# Altium schematic sheet COMPONENT BLOCKS 1.SchDoc
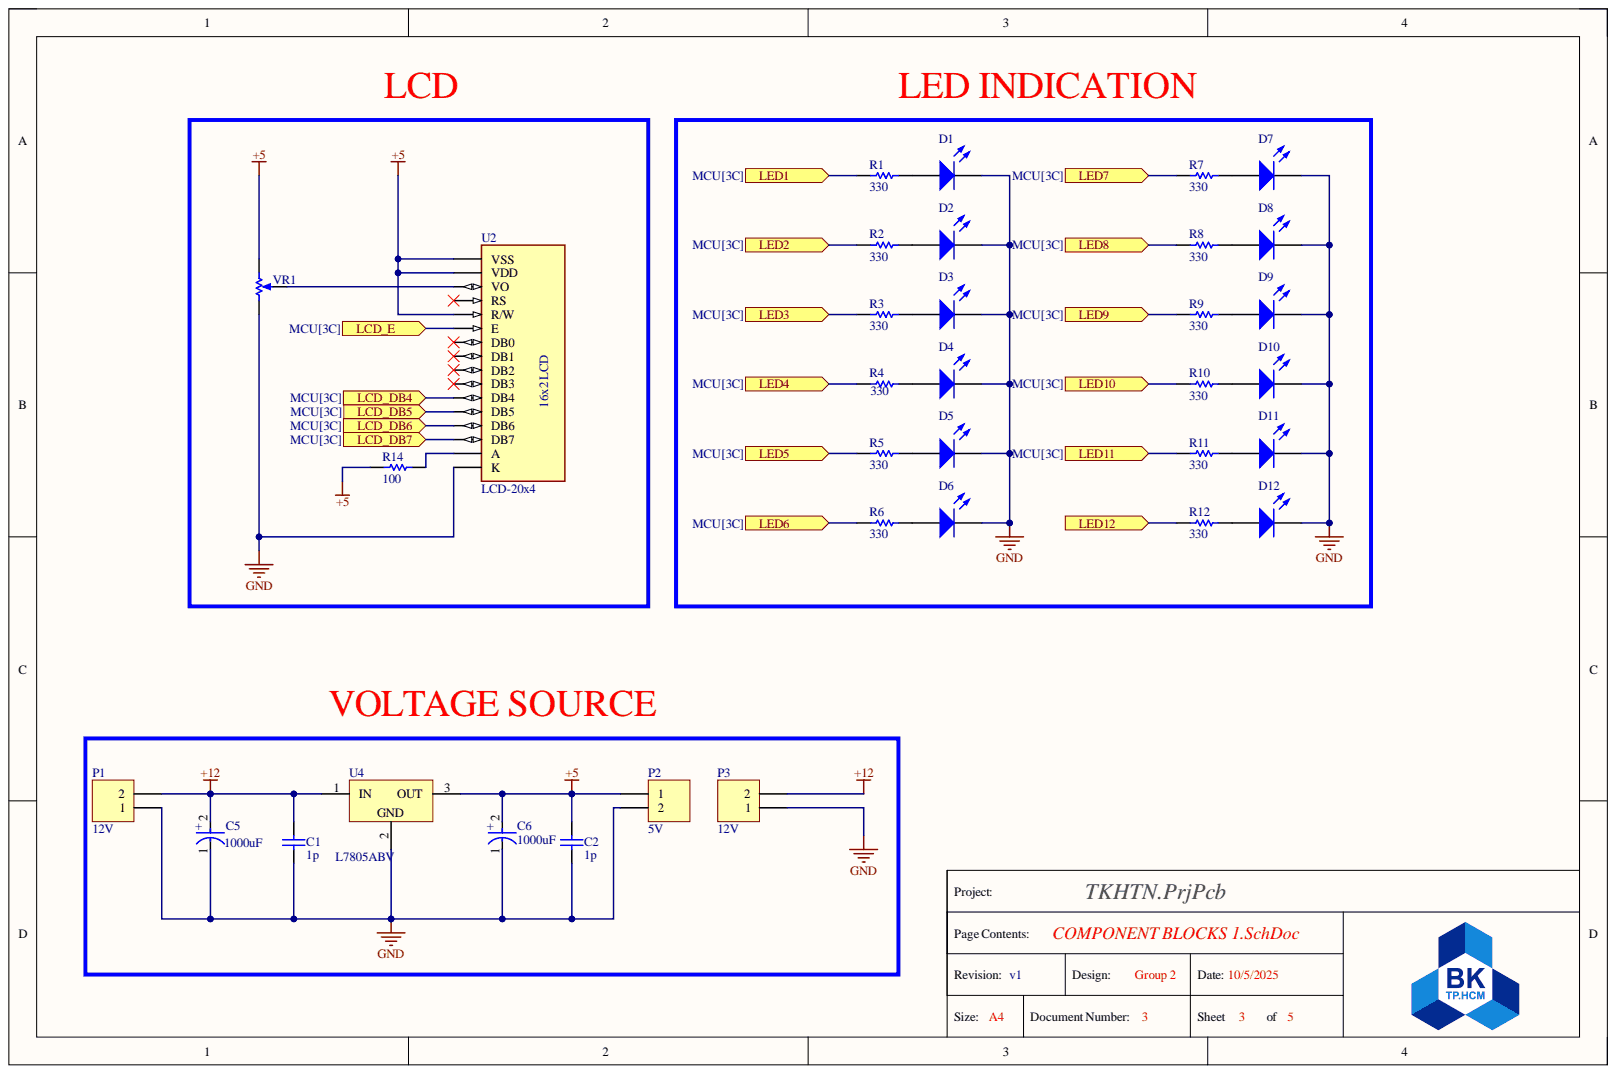
[diagram p0: COMPONENT BLOCKS 1.SchDoc]

## Parts
C1  value 1p  (hidden comment: Capacitor)
C2  value 1p  (hidden comment: Capacitor)
C5  1000uF  [FP-SMR-P45-MFG]
C6  1000uF  [FP-SMR-P45-MFG]
D1  Light-Emitting Diode  (value not drawn)
D2  Light-Emitting Diode  (value not drawn)
D3  Light-Emitting Diode  (value not drawn)
D4  Light-Emitting Diode  (value not drawn)
D5  Light-Emitting Diode  (value not drawn)
D6  Light-Emitting Diode  (value not drawn)
D7  Light-Emitting Diode  (value not drawn)
D8  Light-Emitting Diode  (value not drawn)
D9  Light-Emitting Diode  (value not drawn)
D10  Light-Emitting Diode  (value not drawn)
D11  Light-Emitting Diode  (value not drawn)
D12  Light-Emitting Diode  (value not drawn)
P1  12V  [HDR1X2]
P2  5V  [HDR1X2]
P3  12V  [HDR1X2]
R1  value 330  (hidden comment: Resistor)
R2  value 330  (hidden comment: Resistor)
R3  value 330  (hidden comment: Resistor)
R4  value 330  (hidden comment: Resistor)
R5  value 330  (hidden comment: Resistor)
R6  value 330  (hidden comment: Resistor)
R7  value 330  (hidden comment: Resistor)
R8  value 330  (hidden comment: Resistor)
R9  value 330  (hidden comment: Resistor)
R10  value 330  (hidden comment: Resistor)
R11  value 330  (hidden comment: Resistor)
R12  value 330  (hidden comment: Resistor)
R14  value 100  (hidden comment: Resistor)
U2  LCD-20x4  [MODULE_LCD-16X2]
U4  L7805ABV  [TO220]
VR1  Potentiometer  (value not drawn)

## Ports
LCD_DB4, LCD_DB5, LCD_DB6, LCD_DB7, LCD_E, LED1, LED2, LED3, LED4, LED5, LED6, LED7, LED8, LED9, LED10, LED11, LED12

## Nets
+5: C2.2 C6.2 P2.1 R14.1 U2.1(VSS) U2.2(VDD) U2.5(R/W) U4.3 VR1.2
+12: C1.2 C5.2 P1.2 P3.2 U4.1
GND: C1.1 C2.1 C5.1 C6.1 D1.2 D2.2 D3.2 D4.2 D5.2 D6.2 D7.2 D8.2 D9.2 D10.2 D11.2 D12.2 P1.1 P2.2 P3.1 U2.16(K) U4.2 VR1.1
LCD_DB4: U2.11(DB4) ; port LCD_DB4
LCD_DB5: U2.12(DB5) ; port LCD_DB5
LCD_DB6: U2.13(DB6) ; port LCD_DB6
LCD_DB7: U2.14(DB7) ; port LCD_DB7
LCD_E: U2.6(E) ; port LCD_E
LED1: R1.1 ; port LED1
LED2: R2.1 ; port LED2
LED3: R3.1 ; port LED3
LED4: R4.1 ; port LED4
LED5: R5.1 ; port LED5
LED6: R6.1 ; port LED6
LED7: R7.1 ; port LED7
LED8: R8.1 ; port LED8
LED9: R9.1 ; port LED9
LED10: R10.1 ; port LED10
LED11: R11.1 ; port LED11
LED12: R12.1 ; port LED12
NetD1_1: D1.1 R1.2
NetD2_1: D2.1 R2.2
NetD3_1: D3.1 R3.2
NetD4_1: D4.1 R4.2
NetD5_1: D5.1 R5.2
NetD6_1: D6.1 R6.2
NetD7_1: D7.1 R7.2
NetD8_1: D8.1 R8.2
NetD9_1: D9.1 R9.2
NetD10_1: D10.1 R10.2
NetD11_1: D11.1 R11.2
NetD12_1: D12.1 R12.2
NetR14_2: R14.2 U2.15(A)
NetU2_3: U2.3(VO) VR1.3

Not connected: U2 4(RS) 7(DB0) 8(DB1) 9(DB2) 10(DB3)

## Library data of the parts
C1 C2 = Capacitor: SimulationGenericComponent: True; Component Kind: Standard; Description: Capacitor; Library Name: Simulation Generic Components.IntLib; Simulation: C_Capacitor_Default
C5 C6 = CMP-33768-000007-1: CAP ALUM 270UF 20% 450V SNAP; Life (Hours): 2000Hour; Polarity: Polar; Ripple Current: 1.88A; Capacitance: 1000uF; Case/Package: Radial; Component Kind: Standard; Height - Seated (Max): 1.87inch; Lead Pitch: 0.394inch; Max Operating Temperature: 85°C; Min Operating Temperature: -25°C; Mount: Through Hole; Package Quantity: 200; Packaging: Bulk; Tolerance: 20%; Voltage Rating: 450V; Library Name: capacitor polarized.IntLib
D1-D12 = LED: AF: 1; BV: 0; BV_MAX: 1e99; EG: 1.11; FC: 0.5; IBV: 0.001; IS: 1e-14; MJSW: 0.33; NTUN: 30; SimulationGenericComponent: True; TNOM: 27; XTI: 3; CJO: 0; CJP: 0; Component Kind: Standard; CTA: 0; CTP: 0; Description: Light-Emitting Diode; FCS: 0.5; FV_MAX: 1e99; IKF: 0; IKR: 0; ISR: 1e-14; JSW: 0; JTUN: 0; JTUNSW: 0; KEG: 1; KF: 0; LEVEL: 1; Library Name: Simulation Generic Components.IntLib; N: 1; NBV: 1; NR: 1; NS: 1; PHP: 1; RS: 0; Simulation: diode; TCV: 0; TLEV: 0; TLEVC: 0; TM1: 0; TM2: 0; TPB: 0; TPHP: 0; TRS: 0; TRS2: 0; TT: 0; TTT1: 0; TTT2: 0; VJ: 1; XTITUN: 3
P1-P3 = Header 2: Header, 2-Pin; Component Kind: Standard; LatestRevisionDate: 17-Jul-2002; LatestRevisionNote: Re-released for DXP Platform.; Library Name: Miscellaneous Connectors.IntLib; Signal Integrity: Connector; Publisher: Altium Limited
R1-R12 R14 = Resistor: SimulationGenericComponent: True; TC1: 0; Component Kind: Standard; Description: Resistor; Library Name: Simulation Generic Components.IntLib; Simulation: R_Resistor_Default; TC2: 0
U2 = LCD-16X2: Display Development Tools 20x4 Black on Green Char LCD w Backlight; MF: Gravitech; Check_prices: https://www.snapeda.com/parts/LCD-16X2/Gravitech/view-part/?ref=eda; Component Kind: Standard; Library Name: LCD-16X2.IntLib; MP: LCD-16X2; Package: None; SnapEDA_Link: https://www.snapeda.com/parts/LCD-16X2/Gravitech/view-part/?ref=snap
U4 = CMP-0244-00485-1: Positive Voltage Regulator, 5V, 3-Pin TO-220; Component Kind: Standard; ComponentLink1Description: Manufacturer URL; ComponentLink1URL: http://www.st.com; ComponentLink2Description: Datasheet; ComponentLink2URL: http://www.st.com/internet/com/TECHNICAL_RESOURCES/TECHNICAL_LITERATURE/DATASHEET/CD00000444.pdf; ComponentLink3Description: Package Specification; ComponentLink3URL: http://www.st.com/internet/com/TECHNICAL_RESOURCES/TECHNICAL_LITERATURE/PACKAGE_INFORMATION/CD00004649.pdf; DatasheetVersion: 16/11/2011; Library Name: Voltage source 5v.IntLib; PackageDescription: TO, Thru-Hole, Vertical, Heatsink Mounted; 3 In-Line Leads; Pitch 2.54 mm; PackageReference: TO220; PackageVersion: ver3.0
VR1 = Potentiometer: position: 0.5; SimulationGenericComponent: True; value: 1k; Component Kind: Standard; Description: Potentiometer; Library Name: Simulation Generic Components.IntLib; Simulation: POT
